# Altium schematic sheet swd.SchDoc
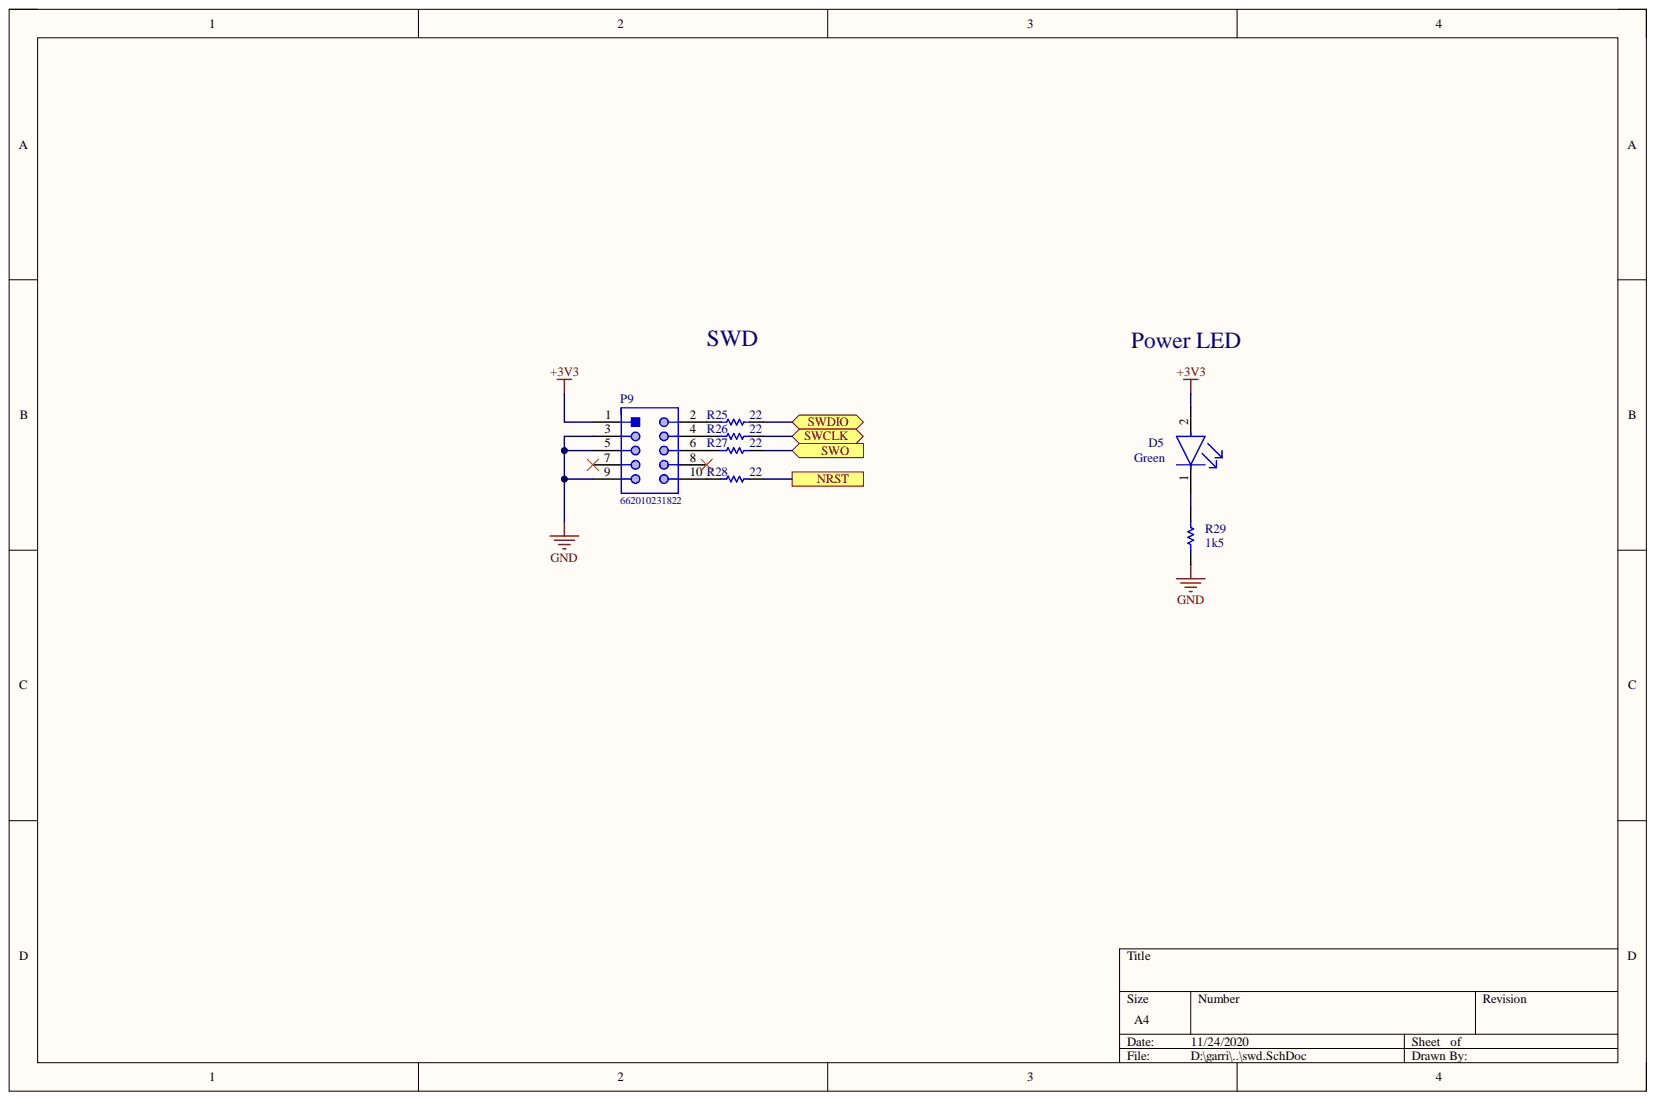
[diagram p0: swd.SchDoc]

## Parts
D5  Green  [Led 0603]
P9  662010231822  [HDRV10W90P254_2X5_1270X483X840P]
R25  22  [Resistor 0402]
R26  22  [Resistor 0402]
R27  22  [Resistor 0402]
R28  22  [Resistor 0402]
R29  1k5  [RESISTOR 0603]

## Ports
NRST, SWCLK, SWDIO, SWO

## Nets
+3V3: D5.2 P9.1
GND: P9.3 P9.5 P9.9 R29.1
NRST: R28.1 ; port NRST
SWCLK: R26.1 ; port SWCLK
SWDIO: R25.1 ; port SWDIO
SWO: R27.1 ; port SWO
NetD5_1: D5.1 R29.2
NetP9_2: P9.2 R25.2
NetP9_4: P9.4 R26.2
NetP9_6: P9.6 R27.2
NetP9_10: P9.10 R28.2

Not connected: P9 7 8

## Library data of the parts
D5 = LED 0805: Manufacturer: Vishay Lite-On; Manufacturer Part Number: LTST-C190GKT; Dominant Wavelength: 569nm; Forward Current: 30mA; Forward Voltage: 2.1V; Lens Color: Colorless; Lens Style: Clear; Luminous Intensity: 6mcd; Number of Elements: 1; Power Dissipation: 100mW; Reverse Voltage: 5V; Test Current: 10mA; Viewing Angle: 130°; Voltage: 2V; Wavelength: 565nm; Case Code (Imperial): 0603; Case Code (Metric): 1608; Case/Package: 0603; Color: Green; Component Kind: Standard; Depth: 0.8mm; Description: LED 0805; Height: 0.8mm; Illumination Color: Green; Lead Free: Lead Free; Length: 1.6mm; Lens Transparency: Clear; Library Name: F4_Flight_Controller.SCHLIB; Max Operating Temperature: 85°C; Min Operating Temperature: -55°C; Mount: Surface Mount; Number of LEDs: 1; Number of Pins: 2; Package Quantity: 1; Packaging: Tape and Reel; Power Rating: 100mW; Radiation Hardening: No; Width: 0.8mm
P9 = Male Header 02x05: SMT Male Header WR-MPC3, with SMT Solder Tabs, Vertical, Dual Row, pitch 3 mm, 10 pins; Manufacturer: Wurth Electronics; Contact Resistance: 10mOhm; Pin Number: 10; Pitch: 3mm; Working Voltage: 250VAC; Component Kind: Standard; Datasheet URL: https://katalog.we-online.de/em/datasheet/6620xx231822.pdf; Gender: Male; Library Name: F4_Flight_Controller.SCHLIB; Manufacturer Part Number: 662010231822; Manufacturer URL: http://www.we-online.de; Mounting Technology: SMT; Operating Temperature: -40to105degC; Orientation: Vertical; Package Reference: 662010231822; Packaging: Tray; Part Number: 662010231822; Publisher: WurthElektronik
R25-R28 = Resistor 0402: Chip Resistor 0402; Manufacturer: Vishay; Manufacturer Part Number: CRCW0402120RFKED; Component Kind: Standard; Library Name: F4_Flight_Controller.SCHLIB; Part Number: CRCW0402120RFKED
R29 = Resistor 0603: Chip Resistor 0603; Manufacturer: Vishay; Manufacturer Part Number: CRCW0402120RFKED; Component Kind: Standard; Library Name: F4_Flight_Controller.SCHLIB; Part Number: CRCW0402120RFKED
